# Altium schematic sheet HDMI.schdoc(HDMI)
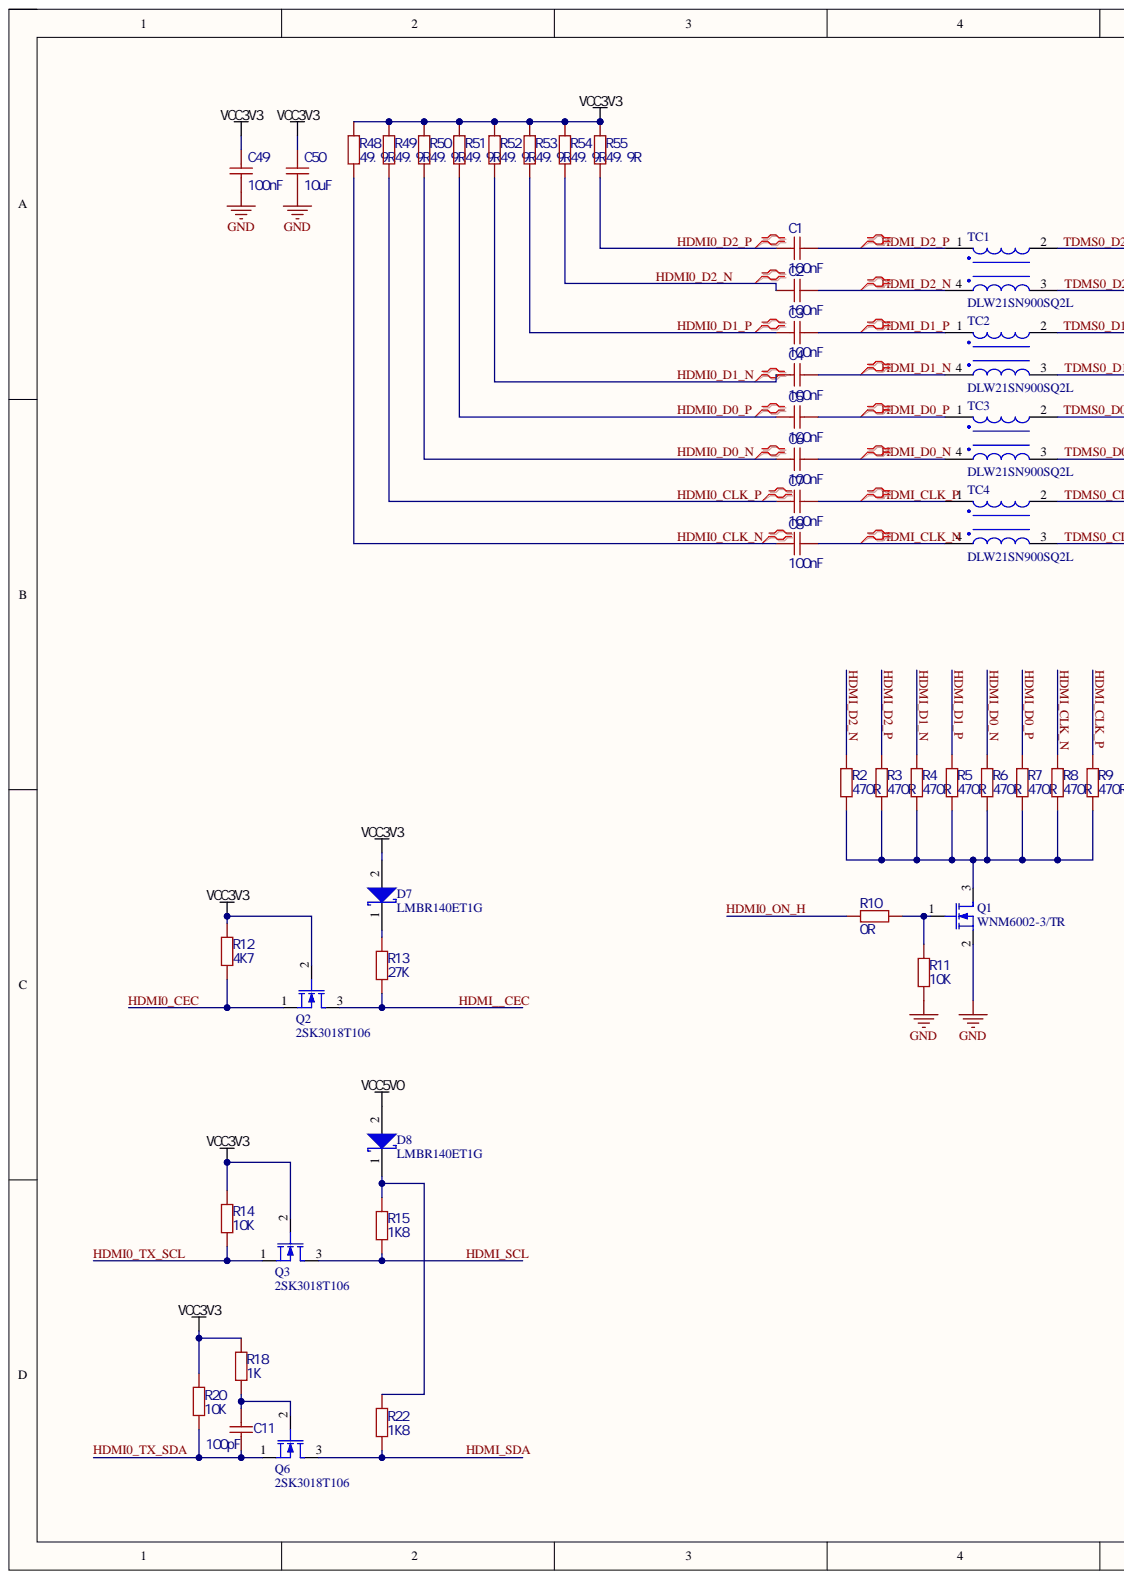
[diagram p0: HDMI.schdoc, left]
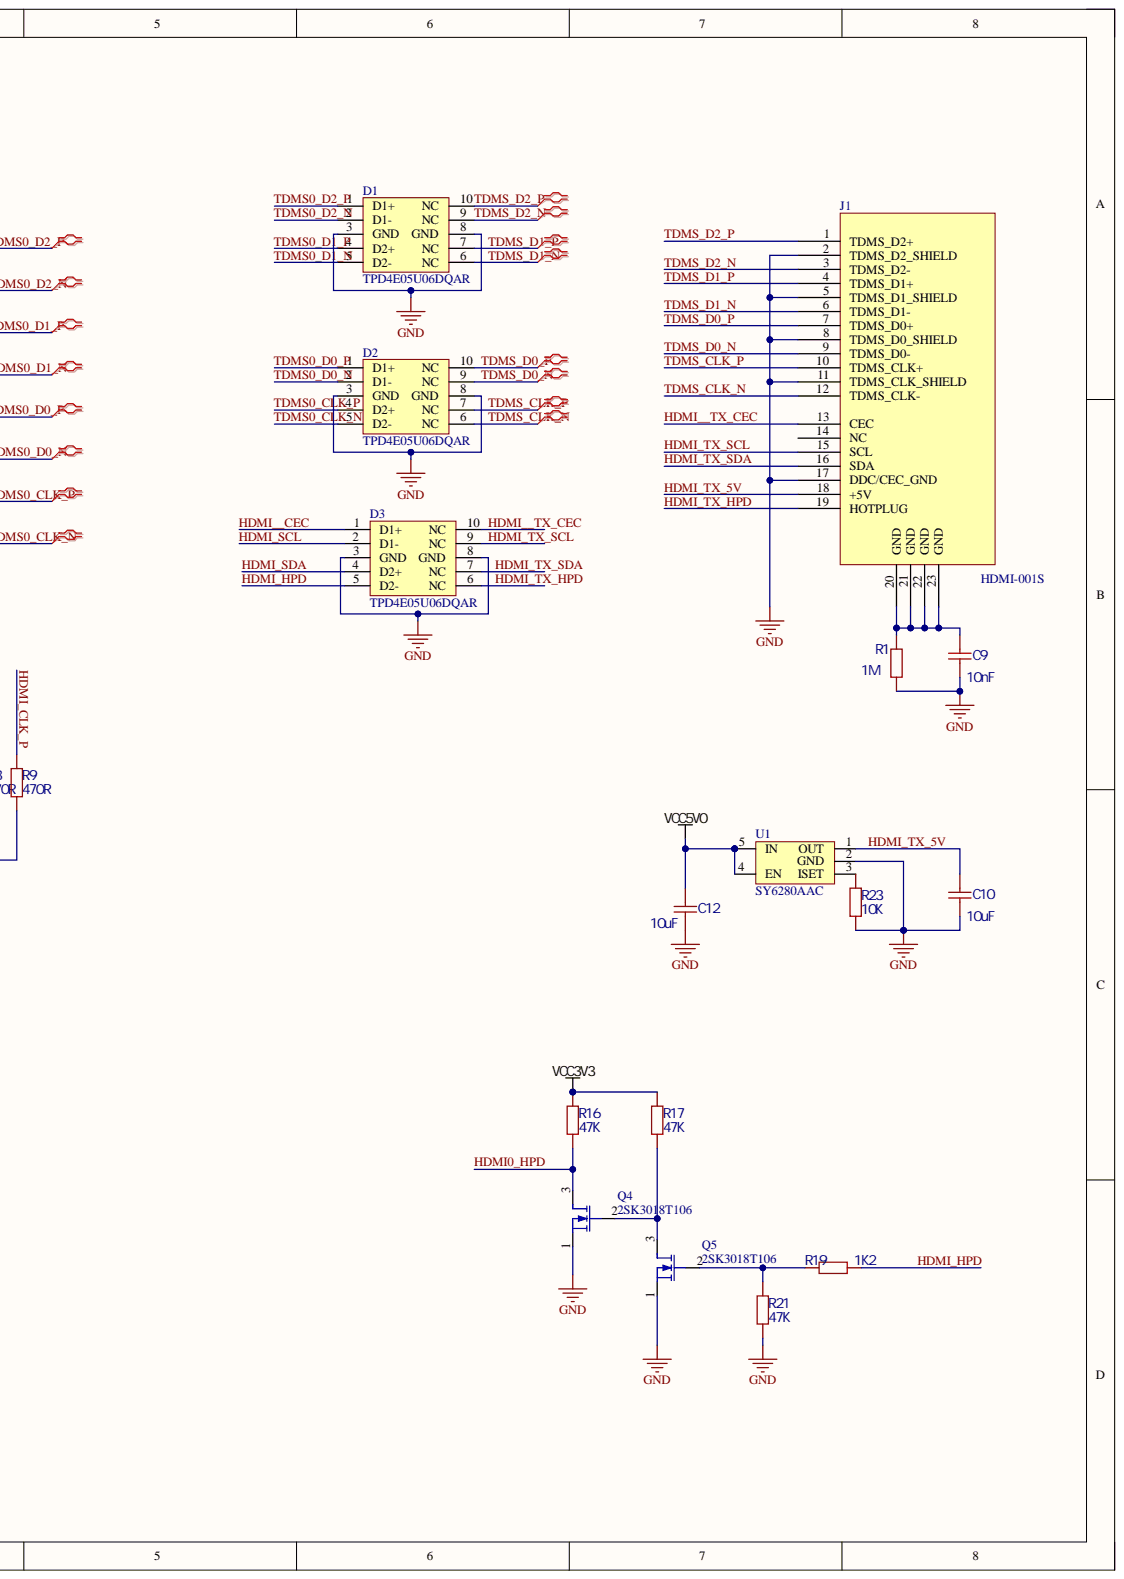
[diagram p1: HDMI.schdoc, right]

## Parts
C1  100nF  [0402]
C2  100nF  [0402]
C3  100nF  [0402]
C4  100nF  [0402]
C5  100nF  [0402]
C6  100nF  [0402]
C7  100nF  [0402]
C8  100nF  [0402]
C9  10nF  [1608[0603]]
C10  10uF  [1608[0603]]
C11  100pF  [0402]
C12  10uF  [1608[0603]]
C49  100nF  [0402]
C50  10uF  value 100nF  [1608[0603]]
D1  TPD4E05U06DQAR  [USON-10_L2.5-W1.0-P0.50-BL]
D2  TPD4E05U06DQAR  [USON-10_L2.5-W1.0-P0.50-BL]
D3  TPD4E05U06DQAR  [USON-10_L2.5-W1.0-P0.50-BL]
D7  LMBR140ET1G  [SOD-323HE_L2.0-W1.4-LS2.5-RD]
D8  LMBR140ET1G  [SOD-323HE_L2.0-W1.4-LS2.5-RD]
J1  HDMI-001S  [HDMI-SMD_HDMI-001S]
Q1  WNM6002-3/TR  [SOT-323-3_L2.0-W1.3-P1.30-LS2.1-BR]
Q2  2SK3018T106  [SOT-323_L2.0-W1.3-P1.30-LS2.1-TR]
Q3  2SK3018T106  [SOT-323_L2.0-W1.3-P1.30-LS2.1-TR]
Q4  2SK3018T106  [SOT-323_L2.0-W1.3-P1.30-LS2.1-TR]
Q5  2SK3018T106  [SOT-323_L2.0-W1.3-P1.30-LS2.1-TR]
Q6  2SK3018T106  [SOT-323_L2.0-W1.3-P1.30-LS2.1-TR]
R1  1M  [1608[0603]]
R2  470R  [0402]
R3  470R  [0402]
R4  470R  [0402]
R5  470R  [0402]
R6  470R  [0402]
R7  470R  [0402]
R8  470R  [0402]
R9  470R  [0402]
R10  0R  [0402]
R11  10K  [0402]
R12  4K7  [0402]
R13  27K  [0402]
R14  10K  [0402]
R15  1K8  [0402]
R16  47K  [0402]
R17  47K  [0402]
R18  1K  [0402]
R19  1K2  [0402]
R20  10K  [0402]
R21  47K  [0402]
R22  1K8  [0402]
R23  10K  [0402]
R48  49.9R  [0402]
R49  49.9R  [0402]
R50  49.9R  [0402]
R51  49.9R  [0402]
R52  49.9R  [0402]
R53  49.9R  [0402]
R54  49.9R  [0402]
R55  49.9R  [0402]
TC1  DLW21SN900SQ2L  [FILTER-SMD_4P-L2.0-W1.2-BL_DLW21H]
TC2  DLW21SN900SQ2L  [FILTER-SMD_4P-L2.0-W1.2-BL_DLW21H]
TC3  DLW21SN900SQ2L  [FILTER-SMD_4P-L2.0-W1.2-BL_DLW21H]
TC4  DLW21SN900SQ2L  [FILTER-SMD_4P-L2.0-W1.2-BL_DLW21H]
U1  SY6280AAC  [SOT-23-5_L3.0-W1.7-P0.95-LS2.8-BL]

## Nets
GND: C9.2 C10.2 C12.2 C49.1 C50.1 D1.3(GND) D1.8(GND) D2.3(GND) D2.8(GND) D3.3(GND) D3.8(GND) J1.2(TDMS_D2_SHIELD) J1.5(TDMS_D1_SHIELD) J1.8(TDMS_D0_SHIELD) J1.11(TDMS_CLK_SHIELD) J1.17(DDC/CEC_GND) Q1.2 Q4.1 Q5.1 R1.1 R11.1 R21.1 R23.1 U1.2(GND)
VCC3V3: C49.2 C50.2 D7.2 Q2.2 Q3.2 R12.2 R14.2 R16.2 R17.2 R18.2 R20.2 R48.1 R49.1 R50.1 R51.1 R52.1 R53.1 R54.1 R55.1
VCC5V0: C12.1 D8.2 U1.4(EN) U1.5(IN)
HDMI0_CEC: Q2.1 R12.1
HDMI0_CLK_N: C8.2 R48.2
HDMI0_CLK_P: C7.2 R49.2
HDMI0_D0_N: C6.2 R50.2
HDMI0_D0_P: C5.2 R51.2
HDMI0_D1_N: C4.2 R52.2
HDMI0_D1_P: C3.2 R53.2
HDMI0_D2_N: C2.2 R54.2
HDMI0_D2_P: C1.2 R55.2
HDMI0_HPD: Q4.3 R16.1
HDMI0_ON_H: R10.1
HDMI0_TX_SCL: Q3.1 R14.1
HDMI0_TX_SDA: C11.2 Q6.1 R20.1
HDMI__CEC: D3.1(D1+) Q2.3 R13.1
HDMI__TX_CEC: D3.10(NC) J1.13(CEC)
HDMI_CLK_N: C8.1 R8.1 TC4.4
HDMI_CLK_P: C7.1 R9.1 TC4.1
HDMI_D0_N: C6.1 R6.1 TC3.4
HDMI_D0_P: C5.1 R7.1 TC3.1
HDMI_D1_N: C4.1 R4.1 TC2.4
HDMI_D1_P: C3.1 R5.1 TC2.1
HDMI_D2_N: C2.1 R2.1 TC1.4
HDMI_D2_P: C1.1 R3.1 TC1.1
HDMI_HPD: D3.5(D2-) R19.2
HDMI_SCL: D3.2(D1-) Q3.3 R15.1
HDMI_SDA: D3.4(D2+) Q6.3 R22.1
HDMI_TX_5V: C10.1 J1.18(+5V) U1.1(OUT)
HDMI_TX_HPD: D3.6(NC) J1.19(HOTPLUG)
HDMI_TX_SCL: D3.9(NC) J1.15(SCL)
HDMI_TX_SDA: D3.7(NC) J1.16(SDA)
TDMS0_CLK_N: D2.5(D2-) TC4.3
TDMS0_CLK_P: D2.4(D2+) TC4.2
TDMS0_D0_N: D2.2(D1-) TC3.3
TDMS0_D0_P: D2.1(D1+) TC3.2
TDMS0_D1_N: D1.5(D2-) TC2.3
TDMS0_D1_P: D1.4(D2+) TC2.2
TDMS0_D2_N: D1.2(D1-) TC1.3
TDMS0_D2_P: D1.1(D1+) TC1.2
TDMS_CLK_N: D2.6(NC) J1.12(TDMS_CLK-)
TDMS_CLK_P: D2.7(NC) J1.10(TDMS_CLK+)
TDMS_D0_N: D2.9(NC) J1.9(TDMS_D0-)
TDMS_D0_P: D2.10(NC) J1.7(TDMS_D0+)
TDMS_D1_N: D1.6(NC) J1.6(TDMS_D1-)
TDMS_D1_P: D1.7(NC) J1.4(TDMS_D1+)
TDMS_D2_N: D1.9(NC) J1.3(TDMS_D2-)
TDMS_D2_P: D1.10(NC) J1.1(TDMS_D2+)
NetC9_1: C9.1 J1.20(GND) J1.21(GND) J1.22(GND) J1.23(GND) R1.2
NetC11_1: C11.1 Q6.2 R18.1
NetD7_1: D7.1 R13.2
NetD8_1: D8.1 R15.2 R22.2
NetQ1_1: Q1.1 R10.2 R11.2
NetQ1_3: Q1.3 R2.2 R3.2 R4.2 R5.2 R6.2 R7.2 R8.2 R9.2
NetQ4_2: Q4.2 Q5.3 R17.1
NetQ5_2: Q5.2 R19.1 R21.2
NetR23_2: R23.2 U1.3(ISET)

Not connected: J1 14(NC)

## Library data of the parts
C1-C8 C49 C50 = 0402B104K500NT: 容值:100nF;精度:±10%;额定电压:50V;材质(温度系数):X7R;; Manufacturer: FH(风华); Add into BOM: yes; Temperature Coefficient: X7R; Tolerance: ±10%; Voltage Rated: 50V; Component Kind: Standard; Convert to PCB: yes; Datasheet: https://atta.szlcsc.com/upload/public/pdf/source/20200305/C486355_26A10D38EF8C2A5C37091D0039909270.pdf; Device: 0402B104K500NT; JLCPCB Part Class: 扩展库; LCSC Part Name: 100nF ±10% 50V; Manufacturer Part: 0402B104K500NT; PCB3D: C0402_L1.0-W0.5-H0.5; Supplier: LCSC; Supplier Footprint: 0402; Supplier Part: C110251; Symbol: 0402B104K500NT
  C1-C8 C49: Value: 100nF
C9-C12 = 0402B104K500NT: 容值:100nF;精度:±10%;额定电压:50V;材质(温度系数):X7R;; Manufacturer: FH(风华); Add into BOM: yes; Temperature Coefficient: X7R; Tolerance: ±10%; Value: 100nF; Voltage Rated: 50V; Component Kind: Standard; Convert to PCB: yes; Datasheet: https://atta.szlcsc.com/upload/public/pdf/source/20200305/C486355_26A10D38EF8C2A5C37091D0039909270.pdf; Device: 0402B104K500NT; JLCPCB Part Class: 扩展库; LCSC Part Name: 100nF ±10% 50V; Manufacturer Part: 0402B104K500NT; PCB3D: C0402_L1.0-W0.5-H0.5; Supplier: LCSC; Supplier Footprint: 0402; Supplier Part: C110251; Symbol: 0402B104K500NT
R1-R23 R48-R55 = RC-02K4422FT: 电阻类型:厚膜电阻;阻值:44.2kΩ;精度:±1%;功率:62.5mW;最大工作电压:50V;温度系数:±100ppm/℃;; Manufacturer: FH(风华); Add into BOM: yes; Overload Voltage (Max): 50V; Power(Watts): 62.5mW; Symbol: RS-02K4422FT; Tolerance: ±1%; Value: 44.2kΩ; Component Kind: Standard; Convert to PCB: yes; Datasheet: https://atta.szlcsc.com/upload/public/pdf/source/20140616/1457707091974.pdf; Device: RC-02K4422FT; JLCPCB Part Class: 扩展库; LCSC Part Name: 厚膜电阻 44.2kΩ ±1% 62.5mW; Manufacturer Part: RC-02K4422FT; Operating Temperature Range: -55℃~+155℃; PCB3D: R0402_L1.0-W0.5-H0.5; Supplier: LCSC; Supplier Footprint: 0402; Supplier Part: C140153; Temperature Coefficient: ±100ppm/℃; Type: 厚膜电阻; Library Name: schdoc1.SCHLIB
D1-D3 = TPD4E05U06DQAR: Component Kind: Standard; Library Name: Schlib1.SchLib
D7 D8 = LMBR140ET1G: Component Kind: Standard; Library Name: Schlib1.SchLib
J1 = HDMI-001S: Component Kind: Standard; Library Name: Schlib1.SchLib
Q1 = WNM6002-3/TR: Component Kind: Standard; Library Name: Schlib1.SchLib
Q2-Q6 = 2SK3018T106: Component Kind: Standard; Library Name: Schlib1.SchLib
TC1-TC4 = DLW21SN900SQ2L: Component Kind: Standard; Library Name: Schlib1.SchLib
U1 = SY6280AAC: Component Kind: Standard; Library Name: Schlib1.SchLib
